# Altium schematic sheet eFuse.SchDoc
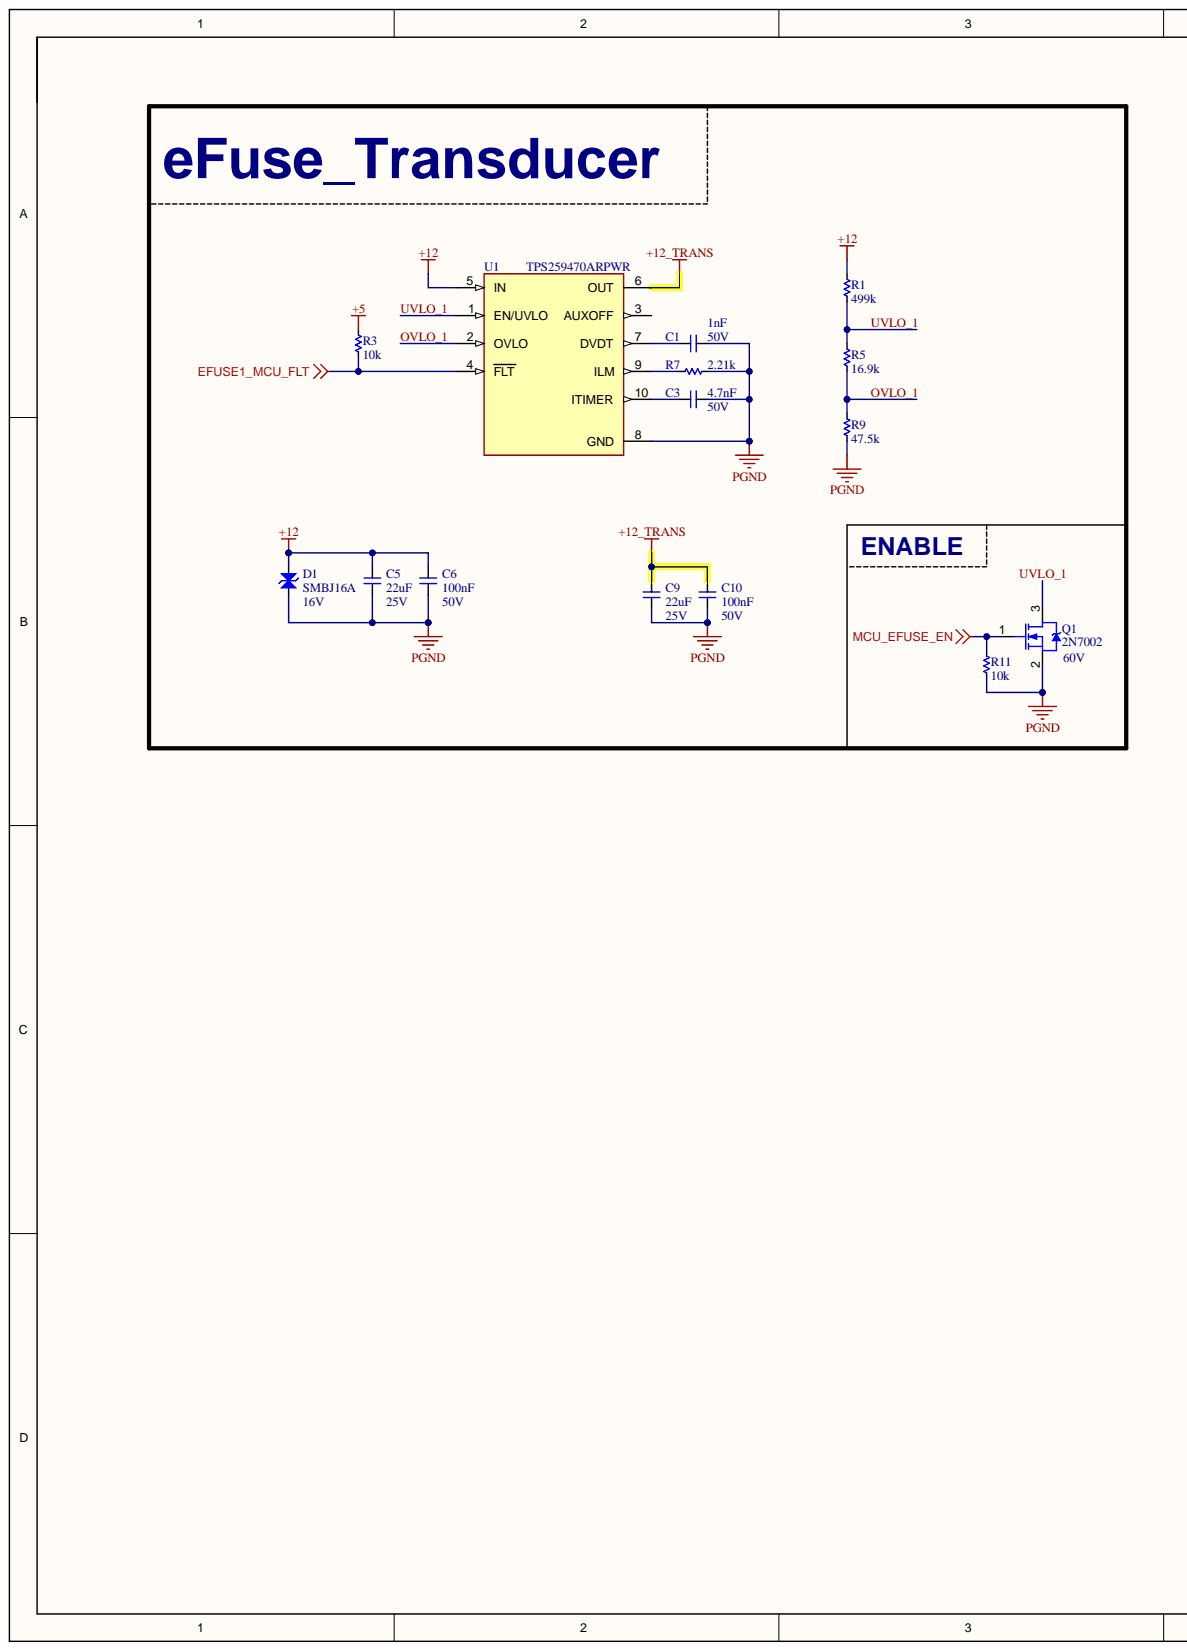
[diagram p0: eFuse.SchDoc, left]
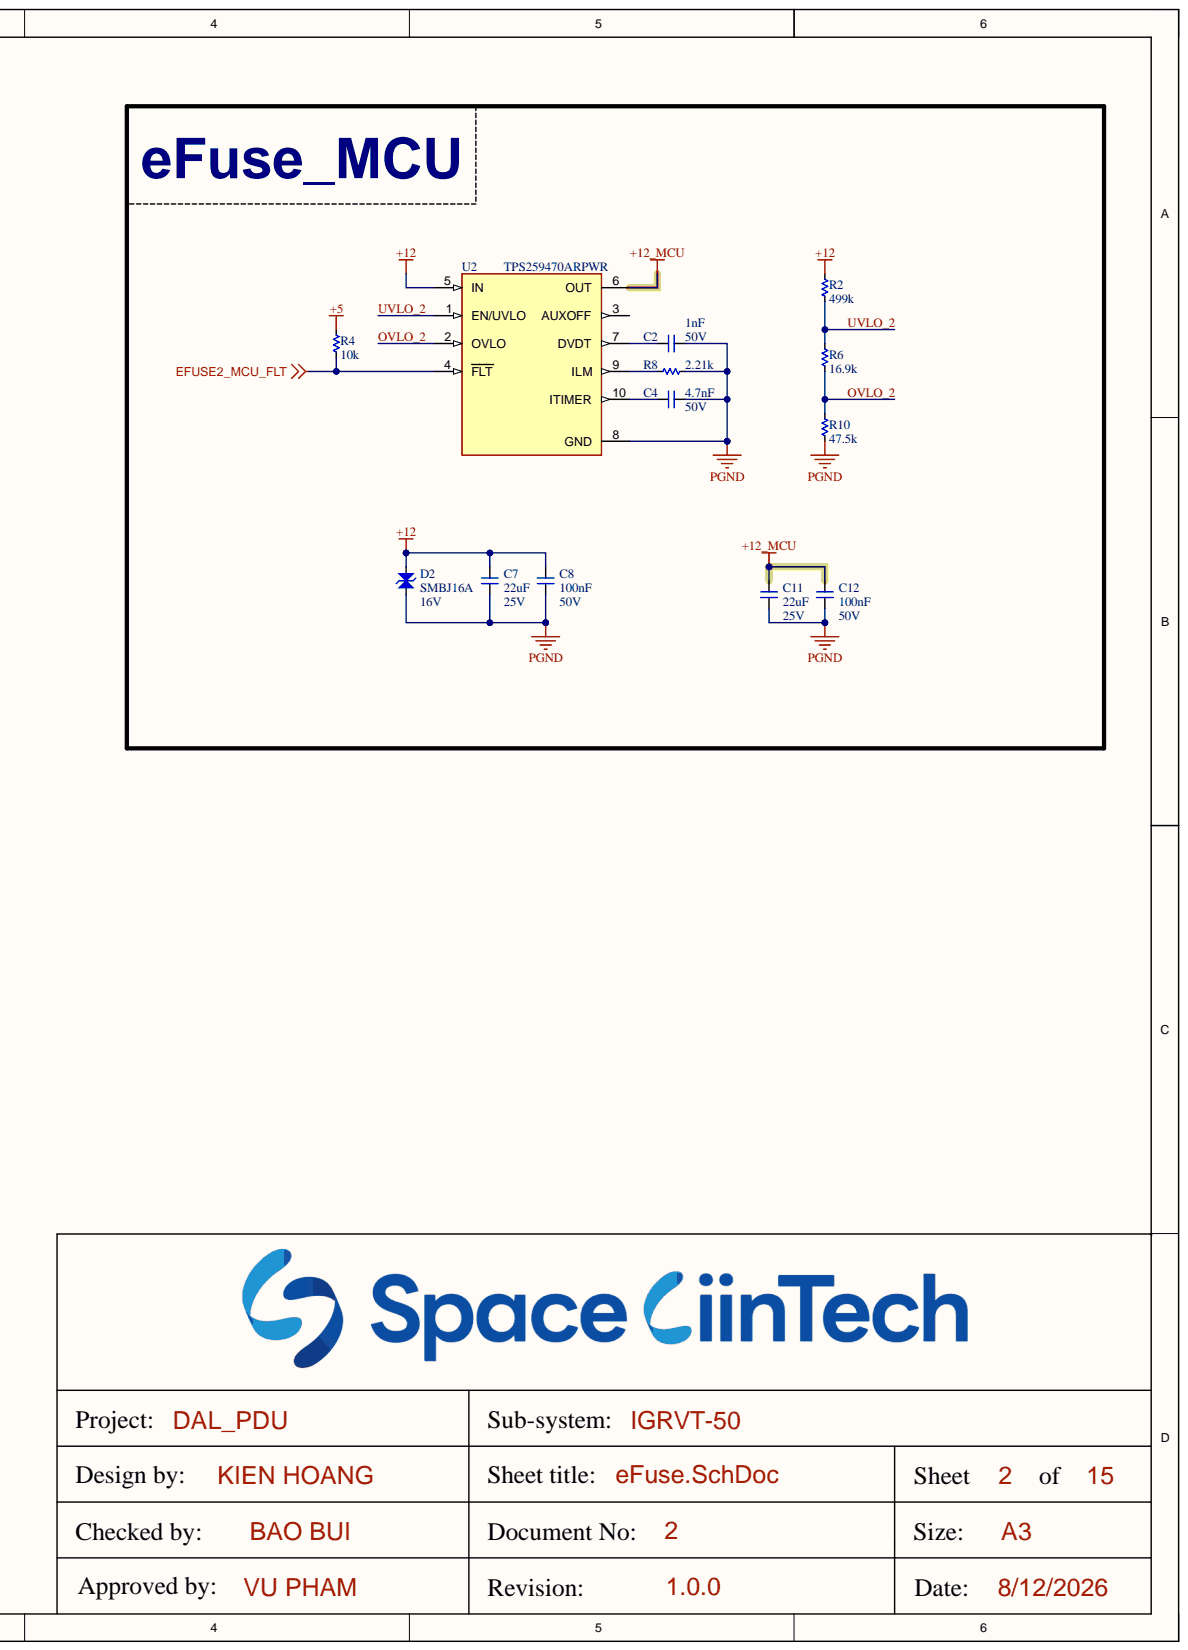
[diagram p1: eFuse.SchDoc, right]

## Parts
C1  1nF  Voltage: 50V  [CAP_C_1608X80]
C2  1nF  Voltage: 50V  [CAP_C_1608X80]
C3  4.7nF  Voltage: 50V  [CAP_C_1608X80]
C4  4.7nF  Voltage: 50V  [CAP_C_1608X80]
C5  22uF  Voltage: 25V  [CAP_C_2012X110]
C6  100nF  Voltage: 50V  [CAP_C_1608X80]
C7  22uF  Voltage: 25V  [CAP_C_2012X110]
C8  100nF  Voltage: 50V  [CAP_C_1608X80]
C9  22uF  Voltage: 25V  [CAP_C_2012X110]
C10  100nF  Voltage: 50V  [CAP_C_1608X80]
C11  22uF  Voltage: 25V  [CAP_C_2012X110]
C12  100nF  Voltage: 50V  [CAP_C_1608X80]
D1  SMBJ16A  Voltage: 16V  [DO-214AA_SMB]
D2  SMBJ16A  Voltage: 16V  [DO-214AA_SMB]
Q1  2N7002  Voltage: 60V  [SOT-23]
R1  499k  [RES_C_1608X60]
R2  499k  [RES_C_1608X60]
R3  10k  [RES_C_1608X60]
R4  10k  [RES_C_1608X60]
R5  16.9k  [RES_C_1608X60]
R6  16.9k  [RES_C_1608X60]
R7  2.21k  [RES_C_1608X60]
R8  2.21k  [RES_C_1608X60]
R9  47.5k  [RES_C_1608X60]
R10  47.5k  [RES_C_1608X60]
R11  10k  [RES_C_1608X60]
U1  TPS259470ARPWR  [TPS259474]
U2  TPS259470ARPWR  [TPS259474]

## Nets
+5: R3.1 R4.1
+12: C5.2 C6.2 C7.2 C8.2 D1.1 D2.1 R1.2 R2.2 U1.5(IN) U2.5(IN)
+12_MCU: C11.2 C12.1 U2.6(OUT)
+12_TRANS: C9.2 C10.1 U1.6(OUT)
EFUSE1_MCU_FLT: R3.2 U1.4(FLT)
EFUSE2_MCU_FLT: R4.2 U2.4(FLT)
MCU_EFUSE_EN: Q1.1 R11.1
PGND: C1.2 C2.2 C3.2 C4.2 C5.1 C6.1 C7.1 C8.1 C9.1 C10.2 C11.1 C12.2 D1.2 D2.2 Q1.2 R7.2 R8.2 R9.1 R10.1 R11.2 U1.8(GND) U2.8(GND)
OVLO_1: R5.1 R9.2 U1.2(OVLO)
OVLO_2: R6.1 R10.2 U2.2(OVLO)
UVLO_1: Q1.3 R1.1 R5.2 U1.1(EN/UVLO)
UVLO_2: R2.1 R6.2 U2.1(EN/UVLO)
NetC1_1: C1.1 U1.7(DVDT)
NetC2_1: C2.1 U2.7(DVDT)
NetC3_1: C3.1 U1.10(ITIMER)
NetC4_1: C4.1 U2.10(ITIMER)
NetR7_1: R7.1 U1.9(ILM)
NetR8_1: R8.1 U2.9(ILM)

Not connected: U1 3(AUXOFF); U2 3(AUXOFF)

## Library data of the parts
C1-C12 = _CAP_C_2: CAP; Component Kind: Standard; Library Name: LABLIB.SchLib
D1 D2 = Diode TVS: TVS Diode; Code - JEDEC: DO-214-AB; Value: 1N5007; Component Kind: Standard; Published: 8-Jun-2000; Revision: July-2002: Re-released for DXP Platform.; Library Name: LABLIB.SchLib; Publisher: Altium Limited
Q1 = Mosfet N: Component Kind: Standard; Description: Mosfet N; Library Name: KIENASIY123_LIB.IntLib
R1-R11 = _RES_B_2_2: RES; Component Kind: Standard; Library Name: LABLIB.SchLib
U1 U2 = TPS259470ARPWR: Component Kind: Standard; Library Name: new1.SchLib
